# Altium schematic sheet Freemotion.SchDoc
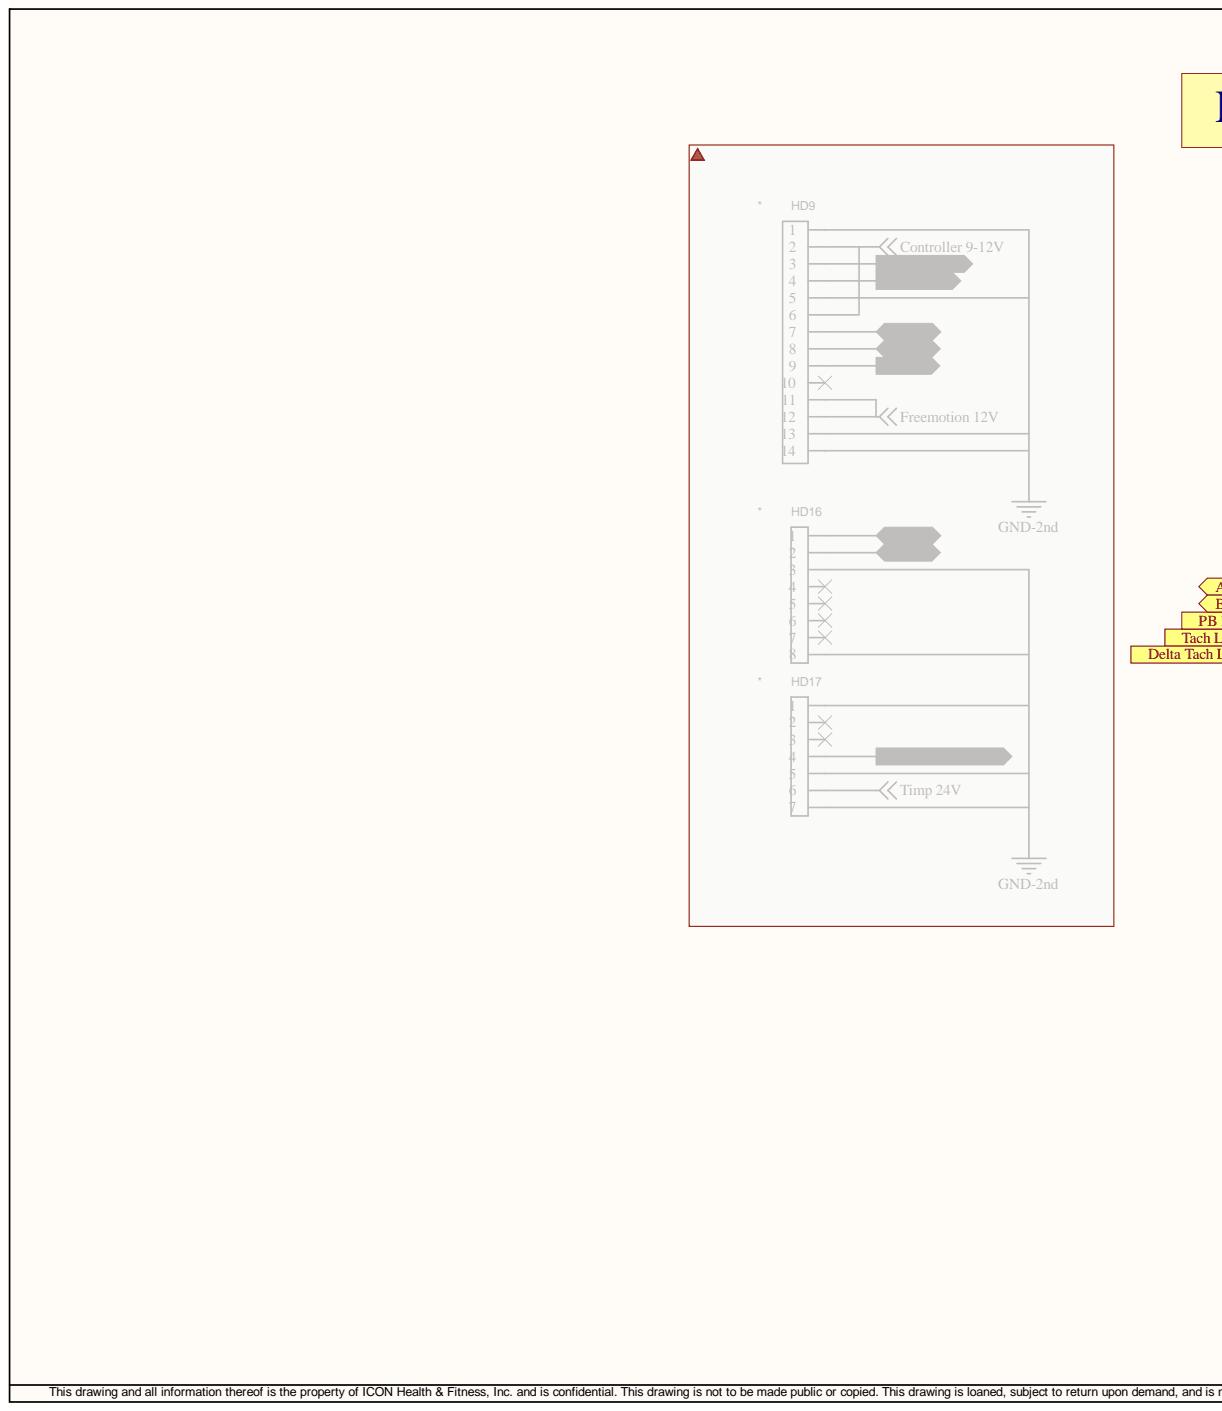
[diagram p0: Freemotion.SchDoc, left]
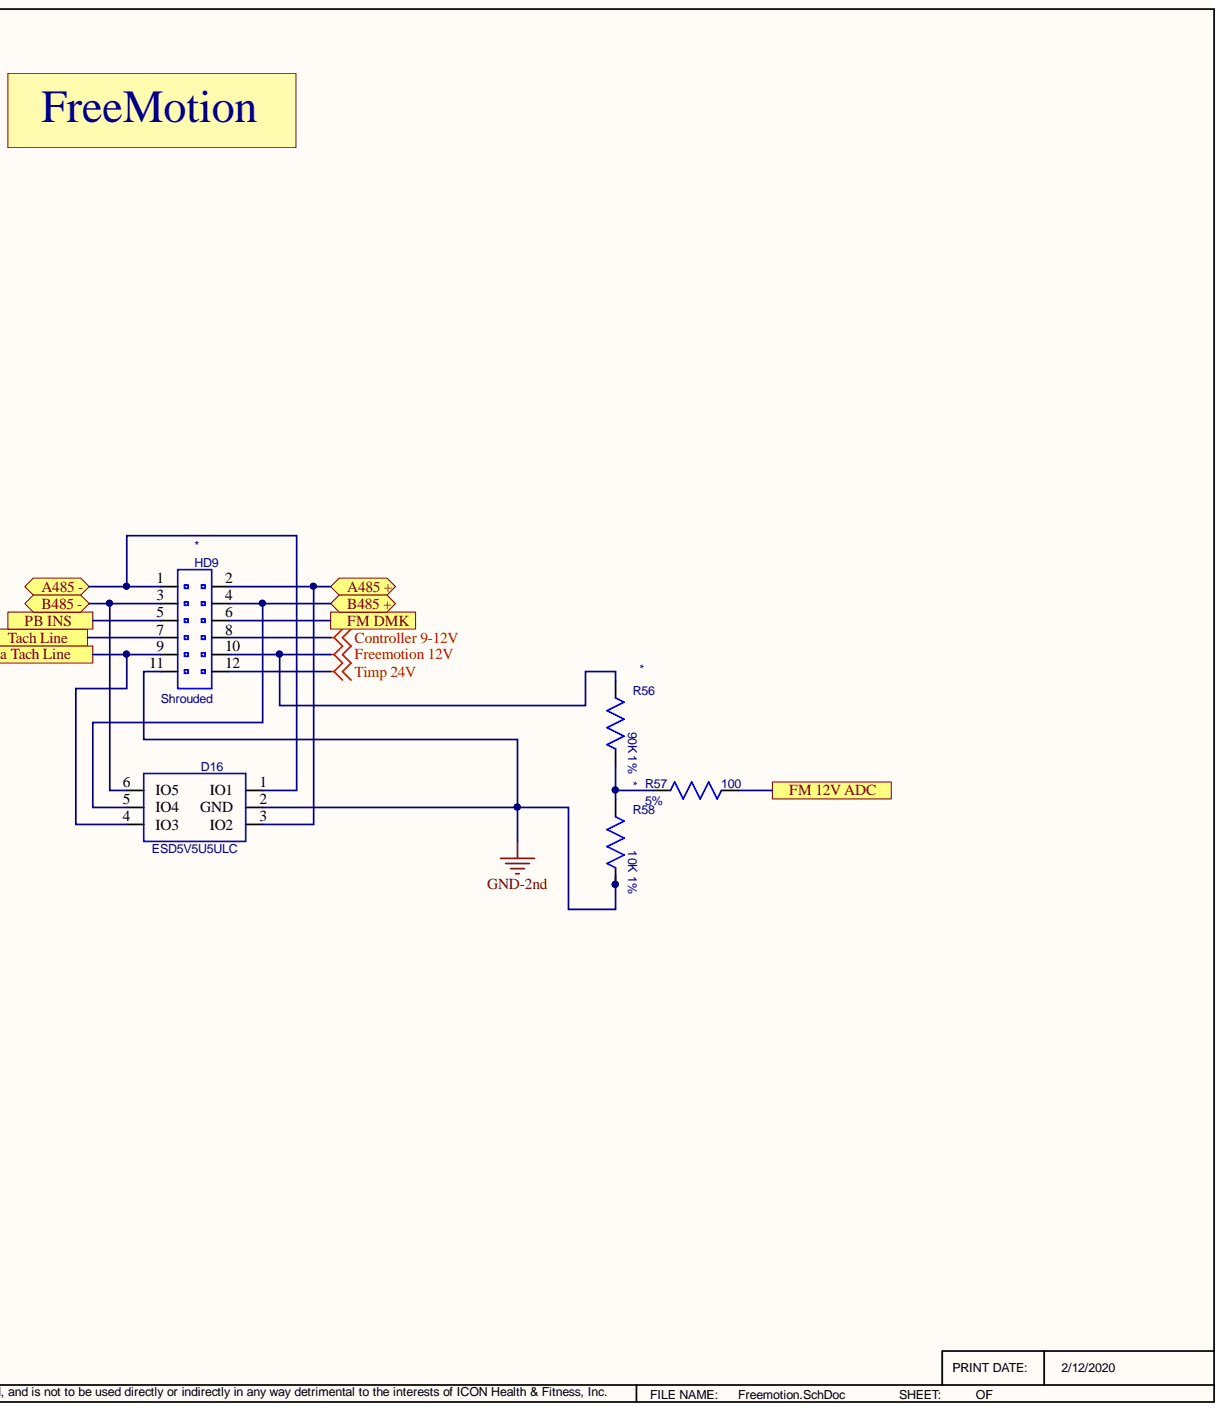
[diagram p1: Freemotion.SchDoc, right]

## Parts
D16  ESD5V5U5ULC  [SOT457-6]
HD9  HeaderType: Shrouded  [HDR_2.5MM_12PIN_JST_XAD]  (hidden comment: HDR_12PIN_DUALROW)
R56  value 90K  Tolerance: 1%  [RC0603REFLOW-IPC]  (hidden comment: R0603REFLOW_1%)
R57  value 100  Tolerance: 5%  [0201]  (hidden comment: Resistor_0201_5%)
R58  value 10K 1%  [RC0603REFLOW-IPC]  (hidden comment: R0603REFLOW_1%)

## Ports
A485 +, A485 -, B485 +, B485 -, Delta Tach Line, FM 12V ADC, FM DMK, PB INS, Tach Line

## Nets
Controller 9-12V: HD9.8
Freemotion 12V: HD9.10 R56.2
GND-2nd: D16.2(GND) HD9.11 R58.1
Timp 24V: HD9.12
Delta Tach Line: D16.4(IO3) HD9.9 ; port Delta Tach Line
FM 12V ADC: R57.1 ; port FM 12V ADC
FM DMK: HD9.6 ; port FM DMK
PB INS: HD9.5 ; port PB INS
Tach Line: HD9.7 ; port Tach Line
NetD16_1: D16.1(IO1) HD9.1
NetD16_3: D16.3(IO2) HD9.2
NetD16_5: D16.5(IO4) HD9.4
NetD16_6: D16.6(IO5) HD9.3
NetR56_1: R56.1 R57.2 R58.2

## Library data of the parts
D16 = 325030: Diode, ESD5V5U5ULC, TVS, 5V, TSOP6, Infineon: ESD5V5U5ULC; Database Table Name: AltiumComponents; IDFCOMP: 0; Component Kind: Standard; ComponentLink1Description: Datasheet; ComponentLink1URL: \\iconfitness.com\loganfiles\RND Departments\electronics\accel_lib\datasheets\Infineon-ESD5V5U5ULC-DS-v01_04-EN.pdf; ComponentLink4Description: PDM Parts Maintenance; ComponentLink4URL: https://pdm.iconfitness.com/PDM/EBOM/PartsMaintenance/PartsMaintenance.aspx?Editplidx=325030; ComponentType: Diode; Cost: 0.08; Datasheet: \\langley\electronics\accel_lib\datasheets\D\PESDXS4UD-NXP.pdf; DiodeType: TVS; ModifyDate: 07/31/2009 12:30:23; Package: TSOP6; plIDX: 325030; Value: ESD5V5U5ULC; Vendor: Infineon: ESD5V5U5ULC; Voltage: 5V; CheckedBy: T. Hayes; CreatedBy: Rui Zhang; LastUpdatedBy: Rui Zhang; Library Name: bome.DbLib
HD9 = 349864: Header, 12 Pins, 2.50mm, Vertical, Through hole, dual row, Shrouded, JST: B12B-XADSS-N-A; Database Table Name: AltiumComponents; Component Kind: Standard; ComponentLink1Description: Datasheet; ComponentLink1URL: \\iconfitness.com\loganfiles\RND Departments\electronics\accel_lib\Datasheets\eXAD1.pdf; ComponentLink4Description: PDM Parts Maintenance; ComponentLink4URL: https://pdm.iconfitness.com/PDM/EBOM/PartsMaintenance/PartsMaintenance.aspx?Editplidx=349864; ComponentType: Header; Cost: 0; LeadSpacing: 2.50mm; Package: Vertical, Through hole, dual row; Pins: 12; plIDX: 349864; Value: B12B-XADSS-N-A; Vendor: JST: B12B-XADSS-N-A; CreatedBy: Rui Zhang; LastUpdatedBy: Rui Zhang; Library Name: bome.DbLib
R56 = 306655: Resistor, 90K, ThickFilm, 1%, 0603, 1/10W, Any; Database Table Name: AltiumComponents; Component Kind: Standard; ComponentLink1Description: Datasheet; ComponentLink1URL: \\iconfitness.com\loganfiles\RND Departments\electronics\accel_lib\Datasheets\yageodocoutput.pdf; ComponentLink4Description: PDM Parts Maintenance; ComponentLink4URL: https://pdm.iconfitness.com/PDM/EBOM/PartsMaintenance/PartsMaintenance.aspx?Editplidx=306655; ComponentType: Resistor; Composition: ThickFilm; Cost: 0.0004; Package: 0603; plIDX: 306655; Vendor: Any; Wattage: 1/10W; CheckedBy: Michael Angeli; CreatedBy: Michael Angeli; LastUpdatedBy: Michael Angeli; Library Name: bome.DbLib
R57 = 304094: Resistor, 100, ThickFilm, 5%, 0201, 1/20W, 2, Any; Database Table Name: AltiumComponents; Component Kind: Standard; ComponentLink4Description: PDM Parts Maintenance; ComponentLink4URL: https://pdm.iconfitness.com/PDM/EBOM/PartsMaintenance/PartsMaintenance.aspx?Editplidx=304094; ComponentType: Resistor; Composition: ThickFilm; Cost: 0; ModifyDate: 4/13/10; Package: 0201; Pins: 2; plIDX: 304094; Vendor: Any; Wattage: 1/20W; CheckedBy: gdeguzman; CreatedBy: Sara Lin; LastUpdatedBy: Sara Lin; Library Name: bome.DbLib
R58 = 5188: Resistor, 10K 1%, ThickFilm, 1%, 0603, 1/10W, Any; Database Table Name: AltiumComponents; Component Kind: Standard; ComponentLink4Description: PDM Parts Maintenance; ComponentLink4URL: https://pdm.iconfitness.com/PDM/EBOM/PartsMaintenance/PartsMaintenance.aspx?Editplidx=5188; ComponentType: Resistor; Composition: ThickFilm; Cost: 0.0004; Package: 0603; plIDX: 5188; Vendor: Any; Wattage: 1/10W; CheckedBy: Michael Angeli; Library Name: bome.DbLib
